# Altium schematic sheet SDRAM.SchDoc
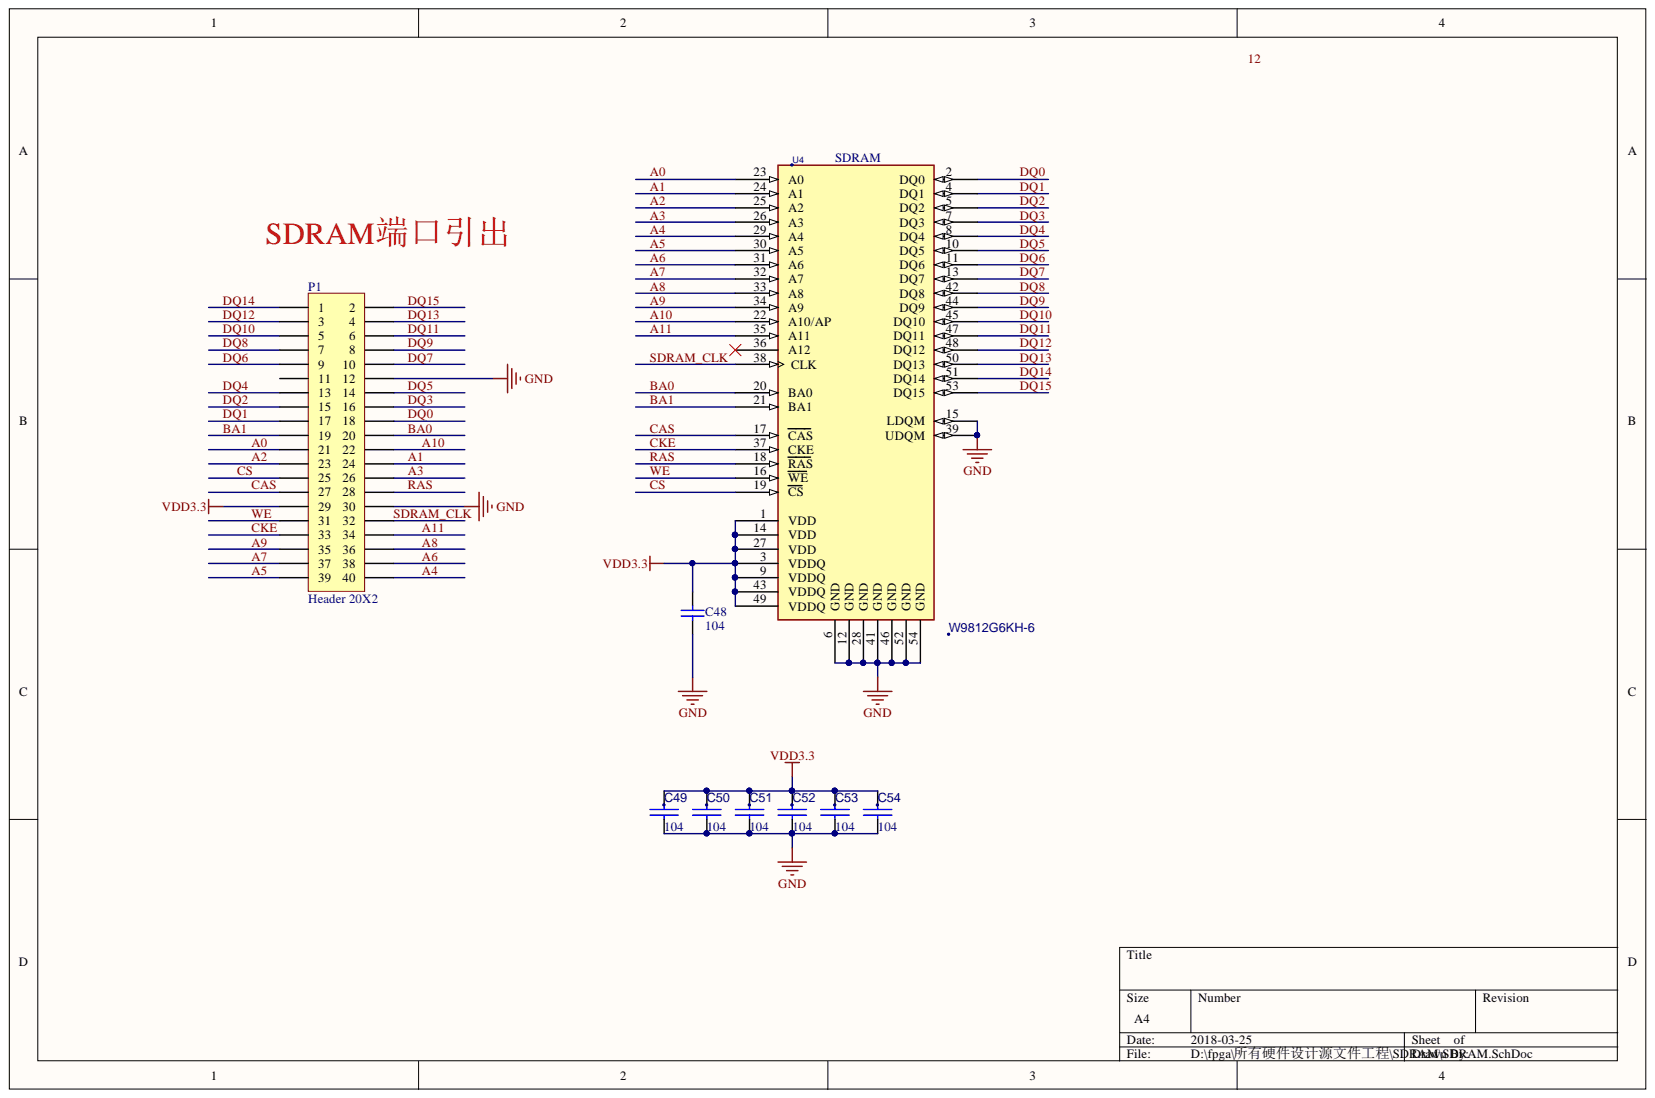
[diagram p0: SDRAM.SchDoc]

## Parts
C48  104  [CAP0603]
C49  104  [CAP0603]
C50  104  [CAP0603]
C51  104  [CAP0603]
C52  104  [CAP0603]
C53  104  [CAP0603]
C54  104  [CAP0603]
P1  Header 20X2  [HDR2X20]
U4  W9812G6KH-6  [TSOP80P1176-54(SDRAM)]

## Nets
GND: C48.1 C49.2 C50.2 C51.2 C52.2 C53.2 C54.2 P1.12 P1.30 U4.6(GND) U4.12(GND) U4.15(LDQM) U4.28(GND) U4.39(UDQM) U4.41(GND) U4.46(GND) U4.52(GND) U4.54(GND)
VDD3.3: C48.2 C49.1 C50.1 C51.1 C52.1 C53.1 C54.1 P1.29 U4.1(VDD) U4.3(VDDQ) U4.9(VDDQ) U4.14(VDD) U4.27(VDD) U4.43(VDDQ) U4.49(VDDQ)
A0: P1.21 U4.23(A0)
A1: P1.24 U4.24(A1)
A2: P1.23 U4.25(A2)
A3: P1.26 U4.26(A3)
A4: P1.40 U4.29(A4)
A5: P1.39 U4.30(A5)
A6: P1.38 U4.31(A6)
A7: P1.37 U4.32(A7)
A8: P1.36 U4.33(A8)
A9: P1.35 U4.34(A9)
A10: P1.22 U4.22(A10/AP)
A11: P1.34 U4.35(A11)
BA0: P1.20 U4.20(BA0)
BA1: P1.19 U4.21(BA1)
CAS: P1.27 U4.17(CAS)
CKE: P1.33 U4.37(CKE)
CS: P1.25 U4.19(CS)
DQ0: P1.18 U4.2(DQ0)
DQ1: P1.17 U4.4(DQ1)
DQ2: P1.15 U4.5(DQ2)
DQ3: P1.16 U4.7(DQ3)
DQ4: P1.13 U4.8(DQ4)
DQ5: P1.14 U4.10(DQ5)
DQ6: P1.9 U4.11(DQ6)
DQ7: P1.10 U4.13(DQ7)
DQ8: P1.7 U4.42(DQ8)
DQ9: P1.8 U4.44(DQ9)
DQ10: P1.5 U4.45(DQ10)
DQ11: P1.6 U4.47(DQ11)
DQ12: P1.3 U4.48(DQ12)
DQ13: P1.4 U4.50(DQ13)
DQ14: P1.1 U4.51(DQ14)
DQ15: P1.2 U4.53(DQ15)
RAS: P1.28 U4.18(RAS)
SDRAM_CLK: P1.32 U4.38(CLK)
WE: P1.31 U4.16(WE)
12:

Not connected: P1 11; U4 36(A12)

## Library data of the parts
C48 = Cap: Capacitor; 贴片和直插: SMT; Component Kind: Standard; Library Name: Miscellaneous Devices.IntLib; PackageDescription: Chip Capacitor; PackageReference: C0603; Simulation: CAP; Value: 104
C49-C54 = C_Dup2: 贴片和直插: SMT; Component Kind: Standard; PackageDescription: Chip Capacitor; PackageReference: C0603; Value: 104
P1 = Header 20X2: Header, 20-Pin, Dual row; Value: IDC40; 贴片和直插: DIP; Component Kind: Standard; Library Name: Miscellaneous Connectors.IntLib; PackageDescription: IDC40, 2.54mm间距，20*2; PackageReference: IDC40
U4 = HY57651620/SO_0: Value: HY57V281620; 贴片和直插: SMT; Component Kind: Standard; PackageDescription: TSOP54; PackageReference: TSOP54
